# Altium schematic sheet PowerSupply.SchDoc(PowerSupply)
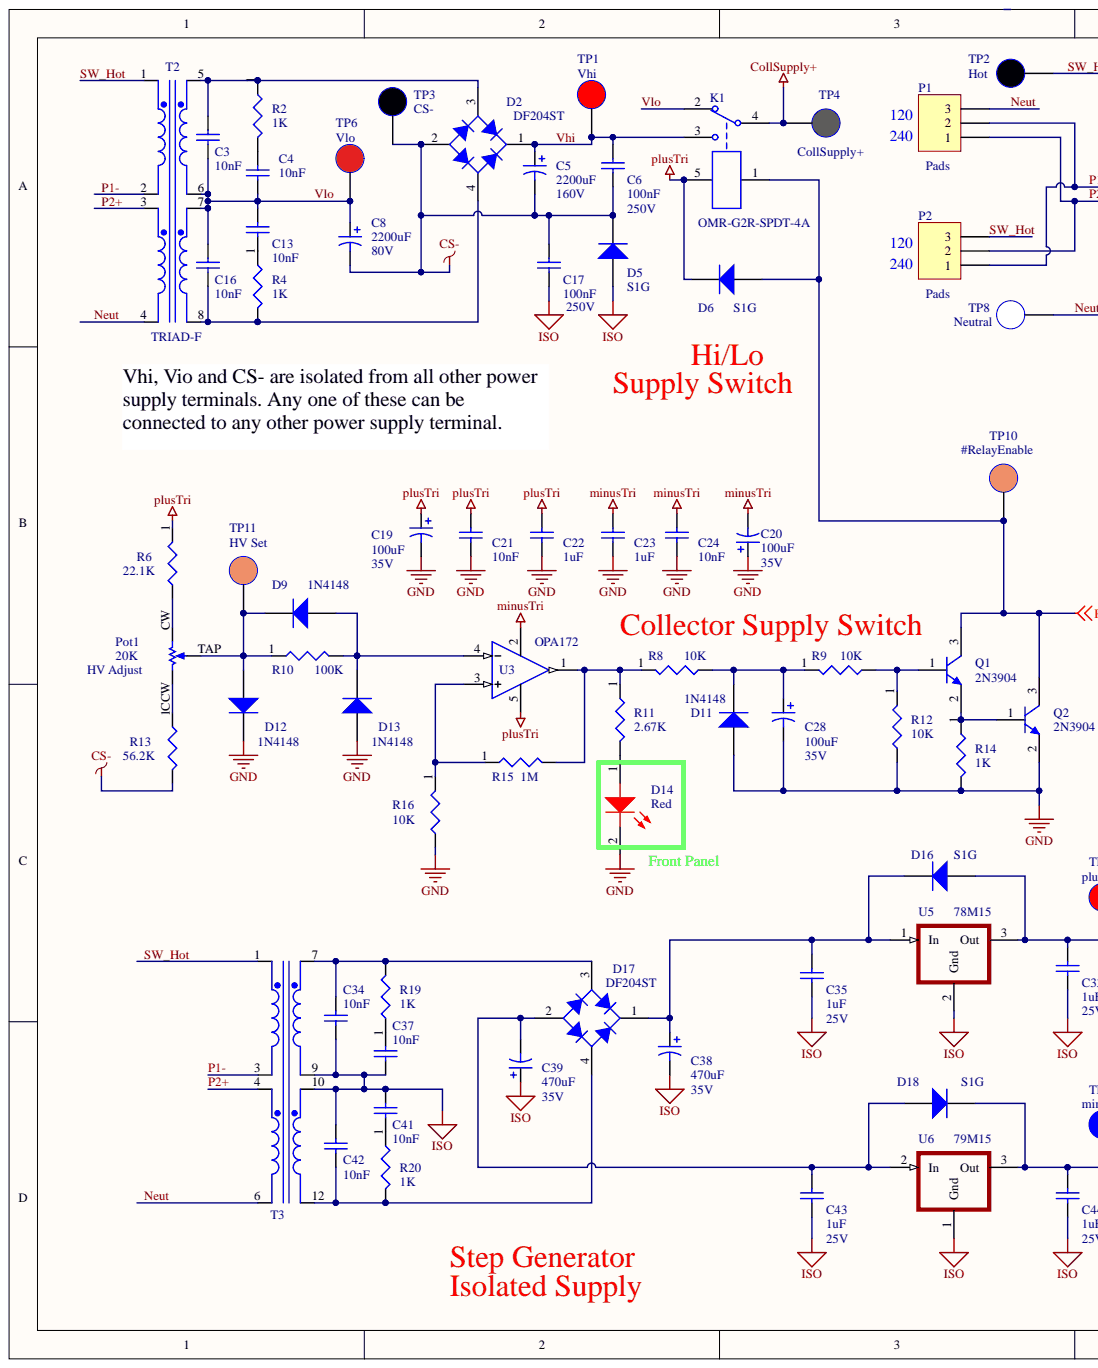
[diagram p0: PowerSupply.SchDoc, left]
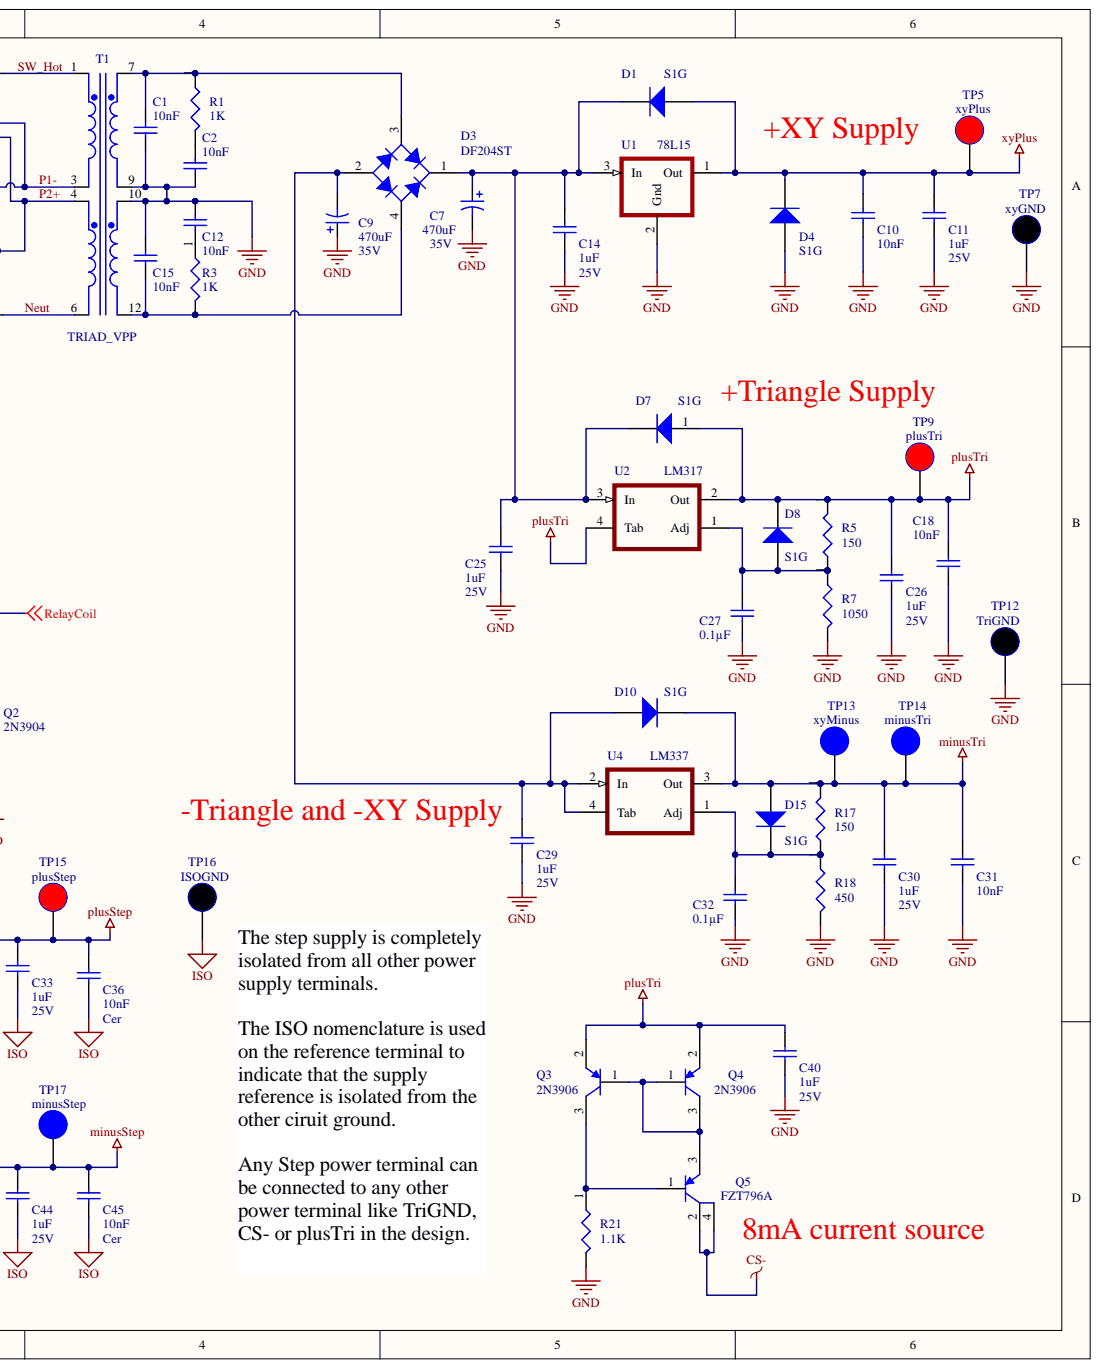
[diagram p1: PowerSupply.SchDoc, right]

## Parts
C1  10nF  [CAPC3216M]
C2  10nF  [CAPC3216M]
C3  Capacitance: 10nF  [CAPC3216M]  (hidden comment: 0.1uF)
C4  Capacitance: 10nF  [CAPC3216M]  (hidden comment: 0.1uF)
C5  2200uF  Voltage Rating (DC): 160V  [CAPR10-35X40]
C6  Capacitance: 100nF  [CAPC3216M]  (hidden comment: 0.1uF)
C7  470uF  [CAPPR5-10x12.5-STEP]
C8  2200uF  Voltage Rating (DC): 80V  [CAPR10-25-37]
C9  470uF  Voltage Rating: 35V  [CAPPR5-10x12.5-STEP]
C10  10nF  [CAPC3216M]
C11  1uF  Voltage Rating (DC): 25V  [CAPC3216M]
C12  10nF  [CAPC3216M]
C13  Capacitance: 10nF  [CAPC3216M]  (hidden comment: 0.1uF)
C14  1uF  Voltage Rating (DC): 25V  [CAPC3216M]
C15  10nF  [CAPC3216M]
C16  Capacitance: 10nF  [CAPC3216M]  (hidden comment: 0.1uF)
C17  Voltage Rating: 250V  Capacitance: 100nF  [CAPC3216M]  (hidden comment: 0.1uF)
C18  10nF  [CAPC3216M]
C19  100uF  Voltage Rating: 35V  [CAPPR2.5-6.3X10]
C20  100uF  Voltage Rating: 35V  [CAPPR2.5-6.3X10]
C21  10nF  [CAPC3216M]
C22  value 1uF  [CAPC3216M]  (hidden comment: Cap)
C23  value 1uF  [CAPC3216M]  (hidden comment: Cap)
C24  10nF  [CAPC3216M]
C25  1uF  Voltage Rating (DC): 25V  [CAPC3216M]
C26  1uF  Voltage Rating (DC): 25V  [CAPC3216M]
C27  Capacitance: 0.1µF  [CAPC3216M]  (hidden comment: 0.1uF)
C28  100uF  Voltage: 35V  [CAPPR2-5x11]
C29  1uF  Voltage Rating (DC): 25V  [CAPC3216M]
C30  1uF  Voltage Rating (DC): 25V  [CAPC3216M]
C31  10nF  [CAPC3216M]
C32  Capacitance: 0.1µF  [CAPC3216M]  (hidden comment: 0.1uF)
C33  1uF  Voltage Rating (DC): 25V  [CAPC3216M]
C34  Capacitance: 10nF  [CAPC3216M]  (hidden comment: 0.1uF)
C35  1uF  Voltage Rating (DC): 25V  [CAPC3216M]
C36  10nF  Dielectric Material: Cer  [CAPC3216M]
C37  Capacitance: 10nF  [CAPC3216M]  (hidden comment: 0.1uF)
C38  470uF  Voltage Rating: 35V  [CAPPR5-10x12.5-STEP]
C39  470uF  Voltage Rating: 35V  [CAPPR5-10x12.5-STEP]
C40  1uF  Voltage Rating (DC): 25V  [CAPC3216M]
C41  Capacitance: 10nF  [CAPC3216M]  (hidden comment: 0.1uF)
C42  Capacitance: 10nF  [CAPC3216M]  (hidden comment: 0.1uF)
C43  1uF  Voltage Rating (DC): 25V  [CAPC3216M]
C44  1uF  Voltage Rating (DC): 25V  [CAPC3216M]
C45  10nF  Dielectric Material: Cer  [CAPC3216M]
D1  S1G  [SMA-D]
D2  value DF204ST  [SOIC254P670X290-4M]  (hidden comment: Bridge)
D3  value DF204ST  [SOIC254P670X290-4M]  (hidden comment: Bridge)
D4  S1G  [SMA-D]
D5  S1G  [SMA-D]
D6  S1G  [SMA-D]
D7  S1G  [SMA-D]
D8  S1G  [SMA-D]
D9  1N4148  [SOD123]
D10  S1G  [SMA-D]
D11  1N4148  [SOD123]
D12  1N4148  [SOD123]
D13  1N4148  [SOD123]
D14  Red  [LED-RED-3MM]
D15  S1G  [SMA-D]
D16  S1G  [SMA-D]
D17  value DF204ST  [SOIC254P670X290-4M]  (hidden comment: Bridge)
D18  S1G  [SMA-D]
K1  OMR-G2R-SPDT-4A  [G2R--1-H]
P1  Pads  [3X1LgH]
P2  Pads  [3X1LgH]
Pot1  HV Adjust  value 20K  [3306P-CCW]
Q1  2N3904  [SOT23-3M]
Q2  2N3904  [SOT23-3M]
Q3  2N3906  [SOT23-3M]
Q4  2N3906  [SOT23-3M]
Q5  FZT796A  [SOT223-4M]
R1  1K  [RESC6432BM]
R2  1K  [RESC6432BM]
R3  1K  [RESC6432BM]
R4  1K  [RESC6432BM]
R5  value 150  [RESC3216M]  (hidden comment: 100)
R6  22.1K  [RESC3216M]
R7  value 1050  [RESC3216M]  (hidden comment: 499)
R8  10K  [RESC3216M]
R9  10K  [RESC3216M]
R10  100k  [RESC3216M]
R11  2.67K  [RESC3216M]
R12  10K  [RESC3216M]
R13  56.2K  [RESC3216M]
R14  1K  [RESC3216M]
R15  1M  [RESC3216M]
R16  10K  [RESC3216M]
R17  value 150  [RESC3216M]  (hidden comment: 100)
R18  value 450  [RESC3216M]  (hidden comment: 499)
R19  1K  [RESC6432BM]
R20  1K  [RESC6432BM]
R21  1.1K  [RESC3216M]
T1  TRIAD_VPP  [VPPXX-5W]
T2  TRIAD-F  [DST-36W]
T3  Power Transformer  [VPPXX-5W]  (value not drawn)
TP1  Vhi  [TP-.038]
TP2  Hot  [TP-.063]
TP3  CS-  [TP-.038]
TP4  CollSupply+  [TP-.038]
TP5  xyPlus  [TP-.038]
TP6  Vlo  [TP-.038]
TP7  xyGND  [TP-.038]
TP8  Neutral  [TP-.063]
TP9  plusTri  [TP-.038]
TP10  #RelayEnable  [TP-.038]
TP11  HV Set  [TP-.038]
TP12  TriGND  [TP-.038]
TP13  xyMinus  [TP-.038]
TP14  minusTri  [TP-.038]
TP15  plusStep  [TP-.038]
TP16  ISOGND  [TP-.038]
TP17  minusStep  [TP-.038]
U1  78L15  [SOT89M]
U2  LM317  [SOT223-4M]
U3  OPA172  [SOT23-5AM]
U4  LM337  [SOT223-4M]
U5  78M15  [DPAK-M]
U6  79M15  [DPAK-M]

## Nets
CollSupply+: K1.4 TP4.1
CS-: C5.2 C6.1 C8.2 C17.2 D2.2 D5.2 Q5.2 Q5.4 R13.2 TP3.1
GND: C1.2 C2.2 C7.2 C9.1 C10.1 C11.1 C12.1 C14.1 C15.1 C18.1 C19.2 C20.1 C21.1 C22.1 C23.1 C24.1 C25.1 C26.1 C27.1 C28.2 C29.1 C30.1 C31.1 C32.1 C40.1 D4.1 D11.1 D12.2 D13.1 D14.2 Q2.2 R7.2 R12.2 R14.2 R16.2 R18.2 R21.2 T1.9 T1.10 TP7.1 TP12.1 U1.2
ISO: C17.1 C33.1 C34.2 C35.1 C36.1 C37.2 C38.2 C39.1 C41.1 C42.1 C43.1 C44.1 C45.1 D5.1 T3.9 T3.10 TP16.1 U5.2 U6.1
minusStep: C44.2 C45.2 D18.2 TP17.1 U6.3
minusTri: C20.2 C23.2 C24.2 C30.2 C31.2 D10.2 D15.1 R17.1 TP13.1 TP14.1 U3.2 U4.3(Out)
plusStep: C33.2 C36.2 D16.1 TP15.1 U5.3
plusTri: C18.2 C19.1 C21.2 C22.2 C26.2 C40.2 D6.2 D7.1 D8.2 K1.5 Q3.2 Q4.2 R5.1 R6.1 TP9.1 U2.2(Out) U2.4(Tab) U3.5
RelayCoil: D6.1 K1.1 Q1.3 Q2.3 TP10.1
xyPlus: C10.2 C11.2 D1.1 D4.2 TP5.1 U1.1
Neut: P1.3 T1.6 T2.4 T3.6 TP8.1
P1-: P1.2 P2.1 T1.3 T2.2 T3.3
P2+: P1.1 P2.2 T1.4 T2.3 T3.4
SW_Hot: P2.3 T1.1 T2.1 T3.1 TP2.1
Vhi: C5.1 C6.2 D2.1 K1.3 TP1.1
Vlo: C3.1 C4.1 C8.1 C13.1 C16.2 K1.2 T2.6 T2.7 TP6.1
NetC1_1: C1.1 D3.3 R1.1 T1.7
NetC2_1: C2.1 R1.2
NetC3_2: C3.2 D2.3 R2.1 T2.5
NetC4_2: C4.2 R2.2
NetC7_1: C7.1 C14.2 C25.2 D1.2 D3.1 D7.2 U1.3 U2.3(In)
NetC9_2: C9.2 C29.2 D3.2 D10.1 U4.2(In) U4.4(Tab)
NetC12_2: C12.2 R3.1
NetC13_2: C13.2 R4.1
NetC15_2: C15.2 D3.4 R3.2 T1.12
NetC16_1: C16.1 D2.4 R4.2 T2.8
NetC27_2: C27.2 D8.1 R5.2 R7.1 U2.1(Adj)
NetC28_1: C28.1 D11.2 R8.2 R9.1
NetC32_2: C32.2 D15.2 R17.2 R18.1 U4.1(Adj)
NetC34_1: C34.1 D17.3 R19.2 T3.7
NetC35_2: C35.2 C38.1 D16.2 D17.1 U5.1
NetC37_1: C37.1 R19.1
NetC39_2: C39.2 C43.2 D17.2 D18.1 U6.2
NetC41_2: C41.2 R20.1
NetC42_2: C42.2 D17.4 R20.2 T3.12
NetD9_1: D9.1 D13.2 R10.2 U3.4
NetD9_2: D9.2 D12.1 Pot1.TAP R10.1 TP11.1
NetD14_1: D14.1 R11.2
NetPot1_CCW: Pot1.CCW R13.1
NetPot1_CW: Pot1.CW R6.2
NetQ1_1: Q1.1 R9.2 R12.1
NetQ1_2: Q1.2 Q2.1 R14.1
NetQ3_1: Q3.1 Q4.1 Q4.3 Q5.3
NetQ3_3: Q3.3 Q5.1 R21.1
NetR8_1: R8.1 R11.1 R15.2 U3.1
NetR15_1: R15.1 R16.1 U3.3
N00018:

## Library data of the parts
C1 C2 C12 C15 = Cap: CAP CER 1206 0.1UF 250V X7R; Manufacturer Part Number: C1206C104KARECAUTO; Manufacturer: KEMET; Tolerance: 10%; Capacitance: 100nF; Voltage Rating: 250V; Value: 10nF; Supplier Part Number: 399-17175-6-ND; Supplier: Digi-Key; Simulation: CAP; Signal Integrity: Cap; Ratings: AEC-Q200; Packaging: Cut Tape; Mount: Surface Mount; Min Operating Temperature: -55°C; Max Operating Temperature: 125°C; Library Name: Miscellaneous Devices.IntLib; Component Kind: Standard; Case Code (Metric): 3216; Case Code (Imperial): 1206; Case/Package: 1206
C3 C4 C6 C13 C16 C17 C34 C37 C41 C42 = Cap: CAP CER 1206 0.1UF 250V X7R; Manufacturer Part Number: C1206C104KARECAUTO; Manufacturer: KEMET; Tolerance: 10%; Value: 0.1uF; Supplier Part Number: 399-17175-6-ND; Supplier: Digi-Key; Simulation: CAP; Signal Integrity: Cap; Ratings: AEC-Q200; Packaging: Cut Tape; Mount: Surface Mount; Min Operating Temperature: -55°C; Max Operating Temperature: 125°C; Library Name: Miscellaneous Devices.IntLib; Component Kind: Standard; Case Code (Metric): 3216; Case Code (Imperial): 1206; Case/Package: 1206
  C3 C4 C6 C13 C16 C34 C37 C41 C42: Voltage Rating: 250V
C5 = Cap Pol3: CAP ALUM 2200UF 20% 160V SNAP; Manufacturer Part Number: SLPX222M160H7P3; Manufacturer: Cornell Dubilier; Test Frequency: 120Hz; Ripple Current: 4.96A; Life (Hours): 3000Hour; Lead Length: 6.3mm; Lead Diameter: 1.5mm; ESR (Equivalent Series Resistance): 90mR; Dielectric Material: Aluminium; Capacitance: 2.2mF; Voltage Rating: 160V; Voltage: 160V; Value: 2200uF; Tolerance: 20%; Termination: Snap in; Supplier Part Number: 338-1593-ND; Supplier: Digi-Key; Simulation: CAP; Signal Integrity: Cap Pol; Packaging: Bulk; Package Quantity: 40; Number of Pins: 2; Mount: Through Hole,PCB; Min Operating Temperature: -40°C; Max Operating Temperature: 85°C; Library Name: Miscellaneous Devices.IntLib; Length: 40mm; Lead Pitch: 0.394inch; Height - Seated (Max): 1.575inch; Height: 40mm; Diameter: 35mm; Component Kind: Standard; Case/Package: Radial
C7 C9 C38 C39 = Cap Pol3: CAP ALUM 470UF 20% 35V RADIAL; Manufacturer Part Number: 35ZL470MEFC10X20; Manufacturer: Rubycon; Ripple Current: 1.82A; Life (Hours): 4000Hour; Leakage Current: 3uA; Lead Diameter: 0.6mm; Impedance: 23mR; Dielectric Material: Aluminium; Voltage: 35V; Value: 470uF; Tolerance: 20%; Termination: Radial; Supplier Part Number: 35ZL470MEFC10X20-ND; Supplier: Digi-Key; Simulation: CAP; Signal Integrity: Cap Pol; REACH SVHC: Unknown; Radiation Hardening: No; Packaging: Bulk; Package Quantity: 1000; Mount: Through Hole; Min Operating Temperature: -40°C; Max Operating Temperature: 105°C; Library Name: Miscellaneous Devices.IntLib; Lead Pitch: 5mm; Lead Free: Lead Free; Height - Seated (Max): 0.866inch; Height: 20mm; Diameter: 10mm; Component Kind: Standard; Case/Package: Radial; Capacitance: 470uF
  C7: Voltage Rating: 35V
C8 = Cap Pol3: CAP ALUM 2200UF 20% 80V SNAP; Manufacturer Part Number: SLPX222M080C3P3; Manufacturer: Cornell Dubilier; Test Frequency: 120Hz; Ripple Current: 2.53A; Life (Hours): 3000Hour; Lead Length: 6.3mm; Lead Diameter: 1.5mm; ESR (Equivalent Series Resistance): 151mR; Dielectric Material: Aluminium; Capacitance: 2.2mF; Voltage Rating: 80V; Voltage: 80V; Value: 2200uF; Tolerance: 20%; Termination: Snap in; Supplier Part Number: 338-1590-ND; Supplier: Digi-Key; Simulation: CAP; Signal Integrity: Cap Pol; REACH SVHC: No SVHC; Packaging: Bulk; Package Quantity: 100; Number of Pins: 2; Mount: Through Hole,PCB; Min Operating Temperature: -40°C; Max Operating Temperature: 85°C; Library Name: Miscellaneous Devices.IntLib; Length: 30mm; Lead Pitch: 10mm; Height - Seated (Max): 1.181inch; Height: 30mm; Diameter: 25mm; Component Kind: Standard; Case/Package: Radial
C10 C18 C21 C24 C31 C36 C45 = Cap: CAP CER 1UF 25V X7R 1206; Manufacturer Part Number: C1206C103K3RACTU; Manufacturer: KEMET; Tolerance: 10%; Width: 1.6mm; Voltage Rating (DC): 25V; Voltage Rating: 25V; Value: 10nF; Thickness: 0.035inch; Termination: SMD/SMT; Supplier Part Number: 399-14644-1-ND; Supplier: Digi-Key; Simulation: CAP; Signal Integrity: Cap; Radiation Hardening: No; Packaging: Tape and Reel; Package Quantity: 4000; Mount: Surface Mount; Min Operating Temperature: -55°C; Max Operating Temperature: 125°C; Library Name: Miscellaneous Devices.IntLib; Length: 3.2mm; Height: 0.78mm; Dielectric: X7R; Depth: 1.6mm; Component Kind: Standard; Case Code (Metric): 3216; Case Code (Imperial): 1206; Case/Package: 1206; Capacitance: 10nF
  C10 C18 C21 C24 C31: Dielectric Material: Cer
C11 C14 C25 C26 C29 C30 C33 C35 C40 C43 C44 = Cap: KEMET         C1206C105K3RACTU            SMD Multilayer Ceramic Capacitor, C Series, 1 F,  10%, X7R, 25 V, 1206 [3216 Metric]; Width: 0.063inch; Voltage Rating: 25V; Voltage: 25V; Value: 1uF; Tolerance: 10%; Thickness: 0.055inch; Termination: SMD/SMT; Supplier Part Number: 399-1255-1-ND; Supplier: Digi-Key; Simulation: CAP; Signal Integrity: Cap; REACH SVHC: No SVHC; Radiation Hardening: No; Packaging: Tape and Reel; Package Quantity: 1; Mount: Surface Mount; Min Operating Temperature: -55°C; Max Operating Temperature: 125°C; Manufacturer Part Number: C1206C105K3RACTU; Manufacturer: KEMET; Library Name: Miscellaneous Devices.IntLib; Length: 3.2mm; Height: 1mm; Dielectric Material: Ceramic; Dielectric: X7R; Depth: 1.6mm; Contact Plating: Tin,Matte; Component Kind: Standard; Case Code (Metric): 3216; Case Code (Imperial): 1206; Case/Package: 1206; Capacitance: 1uF
C19 C20 = Cap Pol1: CAP ALUM 100UF 20% 35V THRU HOLE; Manufacturer Part Number: 35YXJ100M6.3X11; Manufacturer: Rubycon; Ripple Current: 400mA; Polarity: Polar; Life (Hours): 7000Hour; Leakage Current: 3uA; Lead Diameter: 0.5mm; Impedance: 220mR; Voltage: 35V; Value: 100uF; Tolerance: 20%; Termination: Radial; Supplier Part Number: 1189-2260-ND; Supplier: Digi-Key; Simulation: CAP; Signal Integrity: Cap Pol; Radiation Hardening: No; Packaging: Bulk; Package Quantity: 3000; Mount: Through Hole; Min Operating Temperature: -40°C; Max Operating Temperature: 105°C; Library Name: Miscellaneous Devices.IntLib; Lead Pitch: 2.5mm; Height - Seated (Max): 0.492inch; Height: 11mm; Diameter: 6.3mm; Component Kind: Standard; Case/Package: Radial; Capacitance: 100uF
C22 C23 = Cap: CAP CER 1UF 25V X7R 1206; Manufacturer Part Number: C1206C105M3RACTU; Manufacturer: Kemet; Tolerance: ±20%; Dielectric Material: Cer.; Capacitance: 1µF; Voltage - Rated: 25V; Thickness (Max): 0.055" (1.40mm); Temperature Coefficient: X7R; Supplier Part Number: 399-8148-1-ND; Supplier: Digi-Key; Size / Dimension: 0.126" L x 0.063" W (3.20mm x 1.60mm); Simulation: CAP; Signal Integrity: Cap; RoHS: RoHS Compliant; Packaging: Cut Tape (CT); Package / Case: 1206 (3216 Metric); Operating Temperature: -55°C ~ 125°C; Mounting Type: Surface Mount, MLCC; Library Name: Miscellaneous Devices.IntLib; Component Kind: Standard; Category: Capacitors; Applications: General Purpose
C27 C32 = Cap: Capacitor; Manufacturer Part Number: C0805C104K5RACTU; Manufacturer: Kemet; Voltage - Rated: 50V; Tolerance: ±10%; Temperature Coefficient: X7R; Value: 0.1uF; Supplier Part Number: 399-1170-1-ND; Supplier: Digi-Key; Simulation: CAP; Signal Integrity: Cap; Package / Case: 0805 (2012 Metric); Library Name: Miscellaneous Devices.IntLib; Component Kind: Standard
C28 = Cap Pol1: Polarized Capacitor (Radial); Manufacturer Part #: ECA-1VM470; Manufacturer: Panasonic; Type: Alum; Value: 100uF; Simulation: CAP; Signal Integrity: Cap Pol; Published: 8-Jun-2000; PackageReference: RB7.6-15; Library Name: Miscellaneous Devices.IntLib; LatestRevisionNote: Re-released for DXP Platform.; LatestRevisionDate: 17-Jul-2002; Digikey Part #: P5164; Component Kind: Standard; Publisher: Altium Limited
D1 D4-D8 D10 D15 D16 D18 = Diode 1N4002: Diode Switching 400V 1A 2-Pin DO-214AC T/R; Manufacturer: ON Semiconductor / Fairchild; Reverse Recovery Time: 1.8us; Recovery Time: 1800ns; Power Dissipation: 1.4W; Polarity: Standard; Peak Reverse Current: 1uA; Peak Non-Repetitive Surge Current: 30A; Max Surge Current: 40A; Forward Voltage: 1.1V; Element Configuration: Single; Capacitance: 12pF; Width: 2.95mm; Weight: 0.106g; Voltage Rating (DC): 400V; Supplier Part Number: S1GFSCT-ND; Supplier: Digi-Key; Simulation: 1N4002; Signal Integrity: 1N4002; Reverse Voltage: 400V; REACH SVHC: No SVHC; Radiation Hardening: No; Packaging: Tape and Reel; Package Quantity: 1; Output Current: 1A; Number of Pins: 2; Mount: Surface Mount; Min Operating Temperature: -55°C; Max Reverse Voltage (DC): 400V; Max Repetitive Reverse Voltage (Vrrm): 400V; Max Operating Temperature: 150°C; Max Forward Surge Current (Ifsm): 30A; Manufacturer Part Number: S1G; Library Name: Miscellaneous Devices.IntLib; Length: 4.75mm; Lead Free: Lead Free; Height: 2.2mm; Forward Current: 1A; Current Rating: 1A; Contact Plating: Tin,Matte; ComponentLink2URL: http://www.fairchildsemi.com/ds/1N%2F1N4001.pdf; ComponentLink2Description: Datasheet; ComponentLink1URL: http://www.fairchildsemi.com/; ComponentLink1Description: Manufacturer Link; Component Kind: Standard; Case/Package: SMA; Average Rectified Current: 1A
D2 D3 D17 = Bridge1: RECT BRIDGE GPP 400V 2A DFS; Manufacturer Part Number: DF204ST-G; Manufacturer: Comchip; Max Surge Current: 60A; Max Reverse Leakage Current: 10uA; Width: 10.41mm; Supplier Part Number: 641-1464-1-ND; Supplier: Digi-Key; Simulation: BRIDGE; Packaging: Tape and Reel; Package Quantity: 1; Number of Pins: 4; Mount: Surface Mount; Min Operating Temperature: -55°C; Max Operating Temperature: 150°C; Library Name: Miscellaneous Devices.IntLib; Length: 8.64mm; Height: 3.81mm; Component Kind: Standard; Case/Package: SMD/SMT
D9 D11-D13 = Diode 1N4148: DIODE GP 75V 150MA SOD123FL; Manufacturer Part Number: 1N4148WFL-G3-08; Manufacturer: Vishay Semiconductor Diodes Division; Voltage - Forward (Vf) (Max) @ If: 1.2V @ 100mA; Speed: Small Signal =< 200mA (Io), Any Speed; Reverse Recovery Time (trr): 4ns; Diode Type: Standard; Current - Reverse Leakage @ Vr: 5µA @ 75V; Voltage - DC Reverse (Vr) (Max): 75V; Supplier Part Number: 1N4148WFL-G3-08GICT-ND; Supplier Device Package: SOD-123FL; Supplier: Digi-Key; Simulation: 1N4148; Signal Integrity: 1N4148; RoHS: RoHS Compliant; Published: 8-Jun-2000; Packaging: Cut Tape (CT); PackageReference: DO-35; PackageDescription: Diode, Axial; Body 3.8 x 1.9 mm (LxDia. max), Lead Dia. 0.53 mm (max); Package / Case: SOD-123F; Operating Temperature - Junction: 150°C (Max); Mounting Type: Surface Mount; Library Name: Miscellaneous Devices.IntLib; LatestRevisionNote: Re-released for DXP Platform.; LatestRevisionDate: 17-Jul-2002; DatasheetVersion: 1997; Current - Average Rectified (Io): 150mA; ComponentLink2URL: http://www.fairchildsemi.com/ds/1N%2F1N4148.pdf; ComponentLink2Description: Datasheet; ComponentLink1URL: http://www.fairchildsemi.com/; ComponentLink1Description: Manufacturer Link; Component Kind: Standard; Code_JEDEC: DO-204-AH; Category: Discrete Semiconductor Products; Publisher: Altium Limited
K1 = SPDT-OMR-G2R-1-H: RELAY GEN PURPOSE SPDT 5A 12V; Manufacturer Part Number: G2R-1-H-DC12; Manufacturer: Omron; Release Time: 5ms; Relay Type: General Purpose; Operate Time: 15ms; Max Voltage Rating (DC): 125V; Max Voltage Rating (AC): 380V; Coil Type: Non-Latching; Coil Resistance: 400R; Coil Power: 360mW; Coil Current: 30mA; Weight: 17g; Throw Configuration: SPDT; Termination: PC Pin; Supplier Part Number: Z11701-ND; Supplier: Digi-Key; Packaging: Bulk; Package Quantity: 100; Number of Pins: 5; Mount: Through Hole; Min Operating Temperature: -40°C; Max Operating Temperature: 70°C; Max Current Rating: 5A; Library Name: My_Relays.SchLib; Lead Free: Lead Free; Contact Material: Silver; Contact Current Rating: 5A; Component Kind: Standard; Coil Voltage (DC): 12V
P1 P2 = Header 3: Header, 3-Pin; Signal Integrity: Connector; Library Name: Miscellaneous Connectors.IntLib; LatestRevisionNote: Re-released for DXP Platform.; LatestRevisionDate: 17-Jul-2002; Component Kind: Standard; Publisher: Altium Limited
Pot1 = POT-Single: TRIMMER 10K OHM 0.2W TH; Manufacturer Part Number: 3306P-1-103; Manufacturer: Bourns; Voltage Rating (DC): 100V; Tolerance: 25%; Temperature Coefficient: 250ppm/°C; Resistance: 10kR; Power Rating: 200mW; Pitch: 2.5mm; Number of Turns: 1; Lead Diameter: 1mm; Width: 6.81mm; Weight: 360mg; Voltage Rating: 100V; Type: Potentiometer; Termination: Pin; Taper: Linear; Supplier Part Number: 3306P-103-ND; Supplier: Digi-Key; REACH SVHC: No SVHC; Radiation Hardening: No; Packaging: Bulk; Package Quantity: 200; Number of Terminals: 3; Mount: Through Hole,PCB; Min Operating Temperature: -25°C; Military Standard: Not; Max Power Dissipation: 200mW; Max Operating Temperature: 100°C; Length: 6.81mm; Lead Free: Lead Free; Height: 7.62mm; Diameter: 6.81mm; Depth: 8.1mm; Composition: Cermet; Component Kind: Standard; Colour: Blue; Color: Blue; Library Name: MY_POTS.SchLib
Q1 Q2 = 2N3904: TRANS NPN 40V 0.2A SOT23; Manufacturer Part Number: MMBT3904LT1G; Manufacturer: ON Semiconductor; Vce Saturation (Max) @ Ib, Ic: 300mV @ 5mA, 50mA; Power - Max: 300mW; Frequency - Transition: 300MHz; DC Current Gain (hFE) (Min) @ Ic, Vce: 100 @ 10mA, 1V; Current - Collector (Ic) (Max): 200mA; Voltage - Collector Emitter Breakdown (Max): 40V; Transistor Type: NPN; Supplier Part Number: MMBT3904LT1GOSCT-ND; Supplier Device Package: SOT-23-3 (TO-236); Supplier: Digi-Key; Simulation: 2N3904; Signal Integrity: 2N3904; RoHS: RoHS Compliant; Published: 8-Jun-2000; Part Status: Active; Packaging: Cut Tape (CT); PackageVersion: Sep-1998; PackageReference: TO-92A; Package / Case: TO-236-3, SC-59, SOT-23-3; Operating Temperature: -55°C ~ 150°C (TJ); Mounting Type: Surface Mount; Library Name: My_Miscellaneous Devices.SchLib; LatestRevisionNote: PCB Footprint 'BCY-W3/D4.7' replaced; LatestRevisionDate: 15-Jan-2003; DatasheetVersion: 1997; ComponentLink2URL: http://www.fairchildsemi.com/ds/2N%2F2N3904.pdf; ComponentLink2Description: Datasheet; ComponentLink1URL: http://www.fairchildsemi.com/; ComponentLink1Description: Manufacturer Link; Component Kind: Standard; Code_JEDEC: TO-92A; Category: Discrete Semiconductor Products; Publisher: Altium Limited
Q3 Q4 = 2N3906: TRANS PNP 40V 0.2A SOT23; Manufacturer Part Number: MMBT3906LT1G; Manufacturer: ON Semiconductor; Vce Saturation (Max) @ Ib, Ic: 400mV @ 5mA, 50mA; Power - Max: 300mW; Frequency - Transition: 250MHz; DC Current Gain (hFE) (Min) @ Ic, Vce: 100 @ 10mA, 1V; Current - Collector (Ic) (Max): 200mA; Voltage - Collector Emitter Breakdown (Max): 40V; Value: 2N3906; Transistor Type: PNP; Supplier Part Number: MMBT3906LT1GOSCT-ND; Supplier Device Package: SOT-23-3 (TO-236); Supplier: Digi-Key; Simulation: 2N3906; Signal Integrity: 2N3906; RoHS: RoHS Compliant; Packaging: Cut Tape (CT); Package / Case: TO-236-3, SC-59, SOT-23-3; Mounting Type: Surface Mount; Library Name: Miscellaneous Devices.IntLib; Component Kind: Standard; Category: Discrete Semiconductor Products
Q5 = PNP-2C: TRANS PNP 200V 0.5A SOT-223; Manufacturer Part Number: FZT796ATA; Manufacturer: Diodes Zetex; Voltage Rating (DC): -200V; Transition Frequency: 100MHz; Power Dissipation: 2W; Number of Elements: 1; Max Collector Current: 500mA; Emitter Base Voltage (VEBO): -5V; Element Configuration: Single; Current Rating: -500mA; Collector Emitter Saturation Voltage: -300mV; Width: 3.7mm; Weight: 0.000282oz; Value: FZT796A; Supplier Part Number: FZT796ACT-ND; Supplier: Digi-Key; REACH SVHC: No SVHC; Radiation Hardening: No; Polarity: PNP; Packaging: Tape and Reel; Package Quantity: 1000; Number of Pins: 4; Mount: Surface Mount; Min Operating Temperature: -55°C; Max Power Dissipation: 2W; Max Operating Temperature: 150°C; Max Frequency: 100MHz; Max Breakdown Voltage: 200V; Library Name: My_Miscellaneous Devices.SchLib; Length: 6.7mm; Lead Free: Lead Free; Height: 1.65mm; Gain Bandwidth Product: 100MHz; Frequency: 100MHz; Continuous Collector Current: -500mA; Component Kind: Standard; Collector Emitter Voltage (VCEO): 200V; Collector Emitter Breakdown Voltage: 200V; Collector Base Voltage (VCBO): 200V; Case/Package: SOT-223
R1-R4 R14 R19 R20 = Res3: RES 1K OHM 1% 1/4W 1206; Manufacturer Part Number: RMCF1206FT1K00; Manufacturer: Stackpole Electronics; Temperature Coefficient: 100ppm/°C; Resistance: 1kR; Power Rating: 250mW; Width: 0.063inch; Value: 1K; Tolerance: 1%; Supplier Part Number: RMCF1206FT1K00CT-ND; Supplier: Arrow; Simulation: RESISTOR; Signal Integrity: Res; Radiation Hardening: No; Packaging: Tape and Reel; Number of Terminations: 2; Mount: Surface Mount; Min Operating Temperature: -55°C; Military Standard: Not; Max Power Dissipation: 250mW; Max Operating Temperature: 155°C; Library Name: Miscellaneous Devices.IntLib; Length: 3.2mm; Lead Free: Lead Free; Height: 0.028inch; Features: Automotive AEC-Q200; Depth: 1.6mm; Contact Plating: Matte,Tin; Composition: Thick Film; Component Kind: Standard; Case Code (Metric): 3216; Case Code (Imperial): 1206; Case/Package: 1206
R5 R17 = Res3: RES 100 OHM 1% 1/4W 1206; Manufacturer Part Number: RMCF1206FT100R; Manufacturer: Stackpole Electronics; Temperature Coefficient: 100ppm/°C; Resistance: 100R; Power Rating: 250mW; Width: 0.063inch; Tolerance: 1%; Supplier Part Number: RMCF1206FT100RCT-ND; Supplier: Digi-Key; Simulation: RESISTOR; Signal Integrity: Res; Radiation Hardening: No; Packaging: Tape and Reel; Number of Terminations: 2; Mount: Surface Mount; Min Operating Temperature: -55°C; Military Standard: Not; Max Power Dissipation: 250mW; Max Operating Temperature: 155°C; Library Name: Miscellaneous Devices.IntLib; Length: 0.126inch; Lead Free: Lead Free; Height: 0.028inch; Features: Automotive AEC-Q200; Depth: 1.6mm; Contact Plating: Matte,Tin; Composition: Thick Film; Component Kind: Standard; Case Code (Metric): 3216; Case Code (Imperial): 1206; Case/Package: 1206
R6 = Res3: RES 22.1K OHM 1% 1/4W 1206; Manufacturer Part Number: RMCF1206FT22K1; Manufacturer: Stackpole Electronics; Temperature Coefficient: 100ppm/°C; Resistance: 22.1kR; Power Rating: 250mW; Width: 0.063inch; Value: 22.1K; Tolerance: 1%; Supplier Part Number: RMCF1206FT2K21CT-ND; Supplier: Digi-Key; Simulation: RESISTOR; Signal Integrity: Res; Radiation Hardening: No; Packaging: Tape and Reel; Package Quantity: 1; Number of Terminations: 2; Mount: Surface Mount; Min Operating Temperature: -55°C; Military Standard: Not; Max Power Dissipation: 250mW; Max Operating Temperature: 155°C; Library Name: Miscellaneous Devices.IntLib; Length: 0.126inch; Lead Free: Lead Free; Height: 0.028inch; Features: Automotive AEC-Q200; Depth: 1.6mm; Contact Plating: Matte,Tin; Composition: Thick Film; Component Kind: Standard; Case Code (Metric): 3216; Case Code (Imperial): 1206; Case/Package: 1206
R7 R18 = Res3: RES 499 OHM 1% 1/4W 1206; Manufacturer Part Number: RMCF1206FT499R; Manufacturer: Stackpole Electronics; Temperature Coefficient: 100ppm/°C; Resistance: 499R; Power Rating: 250mW; Width: 0.063inch; Tolerance: 1%; Supplier Part Number: RMCF1206FT499RCT-ND; Supplier: Digi-Key; Simulation: RESISTOR; Signal Integrity: Res; Radiation Hardening: No; Packaging: Tape and Reel; Number of Terminations: 2; Mount: Surface Mount; Min Operating Temperature: -55°C; Military Standard: Not; Max Power Dissipation: 250mW; Max Operating Temperature: 155°C; Library Name: Miscellaneous Devices.IntLib; Length: 0.126inch; Lead Free: Lead Free; Height: 0.028inch; Features: Automotive AEC-Q200; Depth: 1.6mm; Contact Plating: Matte,Tin; Composition: Thick Film; Component Kind: Standard; Case Code (Metric): 3216; Case Code (Imperial): 1206; Case/Package: 1206
R8 R9 R12 R16 = Res3: RES 10K OHM 1% 1/4W 1206; Manufacturer Part Number: RMCF1206FT10K0; Manufacturer: Stackpole Electronics; Voltage Rating (DC): 200V; Temperature Coefficient: 100ppm/°C; Resistance: 10kR; Power Rating: 250mW; Width: 0.063inch; Voltage Rating: 200V; Value: 10K; Tolerance: 1%; Termination: SMD/SMT; Supplier Part Number: RMCF1206FT10K0CT-ND; Supplier: Digi-Key; Simulation: RESISTOR; Signal Integrity: Res; REACH SVHC: No SVHC; Radiation Hardening: No; Packaging: Tape and Reel; Package Quantity: 1; Number of Terminations: 2; Number of Pins: 2; Mount: Surface Mount; Min Operating Temperature: -55°C; Military Standard: Not; Max Power Dissipation: 250mW; Max Operating Temperature: 155°C; Library Name: Miscellaneous Devices.IntLib; Length: 3.2mm; Lead Free: Lead Free; Height: 0.028inch; Features: Automotive AEC-Q200; Depth: 1.6mm; Contact Plating: Matte,Tin; Composition: Thick Film; Component Kind: Standard; Case Code (Metric): 3216; Case Code (Imperial): 1206; Case/Package: 1206
R10 = Res3: RES 100K OHM 1% 1/4W 1206; Manufacturer Part Number: RMCF1206FT100K; Manufacturer: Stackpole Electronics; Temperature Coefficient: 100ppm/°C; Resistance: 100kR; Power Rating: 250mW; Width: 0.063inch; Value: 100K; Tolerance: 1%; Supplier Part Number: RMCF1206FT100KCT-ND; Supplier: Digi-Key; Simulation: RESISTOR; Signal Integrity: Res; Radiation Hardening: No; Packaging: Tape and Reel; Number of Terminations: 2; Mount: Surface Mount; Min Operating Temperature: -55°C; Military Standard: Not; Max Power Dissipation: 250mW; Max Operating Temperature: 155°C; Library Name: Miscellaneous Devices.IntLib; Length: 0.126inch; Lead Free: Lead Free; Height: 0.028inch; Features: Automotive AEC-Q200; Depth: 1.6mm; Contact Plating: Matte,Tin; Composition: Thick Film; Component Kind: Standard; Case Code (Metric): 3216; Case Code (Imperial): 1206; Case/Package: 1206
R11 = Res3: RES 2.67K OHM 1% 1/4W 1206; Manufacturer Part Number: RMCF1206FT2K74; Manufacturer: Stackpole Electronics; Temperature Coefficient: 100ppm/°C; Resistance: 2.74kR; Power Rating: 250mW; Width: 0.063inch; Value: 2.67K; Tolerance: 1%; Supplier Part Number: RMCF1206FT2K67CT-ND; Supplier: Digi-Key; Simulation: RESISTOR; Signal Integrity: Res; Radiation Hardening: No; Packaging: Tape and Reel; Number of Terminations: 2; Mount: Surface Mount; Min Operating Temperature: -55°C; Military Standard: Not; Max Power Dissipation: 250mW; Max Operating Temperature: 155°C; Library Name: Miscellaneous Devices.IntLib; Length: 0.126inch; Lead Free: Lead Free; Height: 0.028inch; Features: Automotive AEC-Q200; Depth: 1.6mm; Contact Plating: Matte,Tin; Composition: Thick Film; Component Kind: Standard; Case Code (Metric): 3216; Case Code (Imperial): 1206; Case/Package: 1206
R13 = Res3: RES 56.2K OHM 1% 1/4W 1206; Manufacturer Part Number: RMCF1206FT56K2; Manufacturer: Stackpole Electronics; Temperature Coefficient: 100ppm/°C; Resistance: 56.2kR; Power Rating: 250mW; Width: 0.063inch; Value: 56.2K; Tolerance: 1%; Supplier Part Number: RMCF1206F56K2CT-ND; Supplier: Digi-Key; Simulation: RESISTOR; Signal Integrity: Res; Radiation Hardening: No; Packaging: Tape and Reel; Package Quantity: 1; Number of Terminations: 2; Mount: Surface Mount; Min Operating Temperature: -55°C; Military Standard: Not; Max Power Dissipation: 250mW; Max Operating Temperature: 155°C; Library Name: Miscellaneous Devices.IntLib; Length: 0.126inch; Lead Free: Lead Free; Height: 0.028inch; Features: Automotive AEC-Q200; Depth: 1.6mm; Contact Plating: Tin,Matte; Composition: Thick Film; Component Kind: Standard; Case Code (Metric): 3216; Case Code (Imperial): 1206; Case/Package: 1206
R15 = Res3: RES 1M OHM 1% 1/4W 1206; Manufacturer Part Number: RMCF1206FT1M00; Manufacturer: Stackpole Electronics; Temperature Coefficient: 100ppm/°C; Resistance: 1MR; Power Rating: 250mW; Width: 0.063inch; Value: 1M; Tolerance: 1%; Supplier Part Number: RMCF1206FT1M00CT-ND; Supplier: Digi-Key; Simulation: RESISTOR; Signal Integrity: Res; Radiation Hardening: No; Packaging: Tape and Reel; Number of Terminations: 2; Mount: Surface Mount; Min Operating Temperature: -55°C; Military Standard: Not; Max Power Dissipation: 250mW; Max Operating Temperature: 155°C; Library Name: Miscellaneous Devices.IntLib; Length: 0.126inch; Lead Free: Lead Free; Height: 0.028inch; Features: Automotive AEC-Q200; Depth: 1.6mm; Contact Plating: Matte,Tin; Composition: Thick Film; Component Kind: Standard; Case Code (Metric): 3216; Case Code (Imperial): 1206; Case/Package: 1206
R21 = Res3: RES 1.1K OHM 1% 1/4W 1206; Manufacturer Part Number: RMCF1206FT1K10; Manufacturer: Stackpole Electronics; Temperature Coefficient: 100ppm/°C; Resistance: 1k1R; Power Rating: 250mW; Width: 0.063inch; Value: 1.1K; Tolerance: 1%; Supplier Part Number: RMCF1206FT1K10CT-ND; Supplier: Arrow; Simulation: RESISTOR; Signal Integrity: Res; Radiation Hardening: No; Packaging: Tape and Reel; Number of Terminations: 2; Mount: Surface Mount; Min Operating Temperature: -55°C; Military Standard: Not; Max Power Dissipation: 250mW; Max Operating Temperature: 155°C; Library Name: Miscellaneous Devices.IntLib; Length: 3.2mm; Lead Free: Lead Free; Height: 0.028inch; Features: Automotive AEC-Q200; Depth: 1.6mm; Contact Plating: Matte,Tin; Composition: Thick Film; Component Kind: Standard; Case Code (Metric): 3216; Case Code (Imperial): 1206; Case/Package: 1206
T3 = TRIAD_VPP: Library Name: My_Trans.SchLib; Component Kind: Standard
TP1 TP5 TP9 TP15 = TP-Round-Red: Library Name: MY_TP.SchLib; Component Kind: Standard
TP2 TP3 TP7 TP12 TP16 = TP-Round-Black: Library Name: MY_TP.SchLib; Component Kind: Standard
TP4 = TP-Round-Green: Library Name: MY_TP.SchLib; Component Kind: Standard
TP6 = TP-Round-Orange: Library Name: MY_TP.SchLib; Component Kind: Standard
TP8 = TP-Round-White: Library Name: MY_TP.SchLib; Component Kind: Standard
TP10 TP11 = TP-Round-Yellow: Library Name: MY_TP.SchLib; Component Kind: Standard
TP13 TP14 TP17 = TP-Round-Blue: Library Name: MY_TP.SchLib; Component Kind: Standard
U1 = 78L00-3PIN: TEXAS INSTRUMENTS         UA78L15ACPK            Linear Voltage Regulator, Fixed, 3-Terminal, Positive, 17.5V To 30V In, 15V And 0.1A Out, SOT-89-3; Quiescent Current: 6mA; Power Supply Rejection Ratio (PSRR): 39dB; Output Voltage Accuracy: 5%; Output Current: 100mA; Number of Regulators: 1; Dropout Voltage: 1.7V; Width: 2.5mm; Value: 78L15; Supplier Part Number: 296-12002-1-ND; Supplier: Digi-Key; REACH SVHC: No SVHC; Radiation Hardening: No; Polarity: Positive; Packaging: Tape and Reel; Package Quantity: 1; Output Voltage: 15V; Output Type: Fixed; Number of Pins: 3; Number of Outputs: 1; Nominal Output Voltage: 15V; Min Output Voltage: 15V; Min Operating Temperature: -40°C; Min Input Voltage: 17.5V; Max Output Voltage: 15V; Max Output Current: 100mA; Max Operating Temperature: 125°C; Max Input Voltage: 30V; Manufacturer Part Number: UA78L15ACPK; Manufacturer: Texas Instruments; Length: 4.5mm; Lead Free: Lead Free; Height: 1.5mm; Contact Plating: Tin,Matte; Component Kind: Standard; Case/Package: SOT-89; Accuracy: 5%; Library Name: MY_Vregs.SchLib
U2 = LM317: GLOVE, POLYAMIDE, L/FREE, PU, SIZE 6 - More Details; Manufacturer Part Number: LM317AEMP/NOPB; Manufacturer: TI National Semiconductor; Voltage: 37V; Reference Voltage: 1.262V; Quiescent Current: 60uA; Power Supply Rejection Ratio (PSRR): 80dB; Polarity: Positive; Output Voltage Accuracy: 1%; Output Type: Adjustable; Output Current: 1A; Number of Outputs: 1; Min Output Voltage: 1.2V; Min Input Voltage: 4.2V; Max Input Voltage: 40V; Dropout Voltage: 170mV; Width: 3.56mm; Value: LM317; Supplier Part Number: LM317AEMP/NOPBCT-ND; Supplier: Digi-Key; REACH SVHC: No SVHC; Radiation Hardening: No; Packaging: Tape and Reel; Package Quantity: 1000; Output Voltage: 37V; Number of Pins: 4; Mount: Surface Mount; Min Operating Temperature: -40°C; Max Output Voltage: 37V; Max Output Current: 1A; Max Operating Temperature: 125°C; Length: 6.5mm; Lead Free: Lead Free; Height: 1.6mm; Current Rating: 1A; Component Kind: Standard; Case/Package: SOT-223; Accuracy: 1%; Library Name: MY_Vregs.SchLib
U3 = TLV2371_5SOT23: OPA172IDCKT 10 MHz,10 V/s, 4.5V to 36V, SC-70; Manufacturer Part Number: OPA172IDBVR; Manufacturer: Texas Instruments; Voltage Gain: 130dB; Value: TLV271; Slew Rate: 10V/µs; Power Supply Rejection Ratio (PSRR): -120dB; Output Current per Channel: 75mA; Operating Supply Current: 1.6mA; Number of Circuits: 1; Min Dual Supply Voltage: 2.25V; Max Dual Supply Voltage: 18V; Input Offset Voltage (Vos): 200uV; Input Bias Current: 8pA; Gain Bandwidth Product: 10MHz; Common Mode Rejection Ratio: 90dB; Supplier Part Number: 296-40433-1-ND; Supplier: Digi-Key; Simulation: TLV2371; REACH SVHC: No SVHC; Packaging: Tape and Reel; Number of Pins: 5; Number of Channels: 1; Number of Amplifiers: 1; Mount: Surface Mount; Min Supply Voltage: 4.5V; Min Operating Temperature: -40°C; Max Supply Voltage: 36V; Max Operating Temperature: 125°C; Lead Free: Lead Free; Contact Plating: Gold; Component Kind: Standard; Case/Package: SOT-23-5; Bandwidth: 10MHz; Library Name: TI-OPAMPS.SchLib
U4 = LM337: TEXAS INSTRUMENTS   LM337IMP/NOPB   V REG ADJ -1.2/37V, SOT-223-4, 337, REEL; Tolerance: 3%; Reference Voltage: -1.287V; Quiescent Current: 3mA; Power Supply Rejection Ratio (PSRR): 77dB; Power Dissipation: 2W; Polarity: Negative; Output Voltage: -37V; Output Type: Adjustable; Output Current: 1A; Number of Outputs: 1; Min Input Voltage: -4.2V; Max Output Voltage: -1.2V; Max Input Voltage: -50V; Dropout Voltage: 2.4V; Width: 3.56mm; Weight: 0.006632oz; Value: LM337; Supplier Part Number: LM337IMP/NOPBCT-ND; Supplier: Digi-Key; REACH SVHC: No SVHC; Packaging: Tape and Reel; Number of Pins: 4; Mount: Surface Mount; Min Output Voltage: -37V; Min Operating Temperature: -40°C; Max Power Dissipation: 2W; Max Output Current: 1A; Max Operating Temperature: 125°C; Manufacturer Part Number: LM337IMP/NOPB; Manufacturer: Texas Instruments; Length: 6.5mm; Lead Free: Lead Free; Height: 1.6mm; Component Kind: Standard; Case/Package: SOT-223; Library Name: MY_Vregs.SchLib
U5 = 78M00-3PIN: IC REG LINEAR 15V 500MA DPAK; Manufacturer Part Number: MC78M15CDTRKG; Manufacturer: ON Semiconductor; Power Supply Rejection Ratio (PSRR): 70dB; Polarity: Positive; Output Type: Fixed; Number of Regulators: 1; Min Input Voltage: 17V; Max Input Voltage: 35V; Dropout Voltage: 2V; Width: 6.22mm; Value: 78M15; Supplier Part Number: MC78M15CDTRKGOSDKR-ND; Supplier: Digi-Key; REACH SVHC: No SVHC; Radiation Hardening: No; Packaging: Tape and Reel; Package Quantity: 1; Output Voltage: 15V; Output Current: 500mA; Number of Pins: 3; Number of Outputs: 1; Nominal Output Voltage: 15V; Mount: Surface Mount; Min Output Voltage: 15V; Min Operating Temperature: 0°C; Max Output Current: 500mA; Max Operating Temperature: 125°C; Length: 6.73mm; Lead Free: Lead Free; Height: 2.38mm; Halogen Free: Halogen Free; Current Rating: 500mA; Contact Plating: Tin,Matte; Component Kind: Standard; Case/Package: DPAK; Library Name: MY_Vregs.SchLib
U6 = 79M00-3PIN: ON SEMICONDUCTOR - MC79M15CDTRKG - NEGATIVE VOLTAGE REGULATOR, -15V DPAK-3; Power Supply Rejection Ratio (PSRR): 60dB; Output Voltage Accuracy: 4%; Output Type: Fixed; Output Current: 500mA; Number of Regulators: 1; Min Input Voltage: -17V; Max Output Voltage: -15.6V; Max Input Voltage: -35V; Dropout Voltage: 1.1V; Value: 79M15; Supplier Part Number: MC79M15CDTRKGOSDKR-ND; Supplier: Digi-Key; REACH SVHC: No SVHC; Radiation Hardening: No; Polarity: Negative; Packaging: Tape and Reel; Package Quantity: 1; Output Voltage: -15V; Number of Pins: 3; Number of Outputs: 1; Nominal Output Voltage: -15V; Mount: Surface Mount; Min Output Voltage: -15V; Min Operating Temperature: 0°C; Max Output Current: 500mA; Max Operating Temperature: 125°C; Manufacturer Part Number: MC79M15CDTRKG; Manufacturer: ON Semiconductor; Lead Free: Lead Free; Halogen Free: Halogen Free; Contact Plating: Tin,Matte; Component Kind: Standard; Case/Package: DPAK; Accuracy: 4%; Library Name: MY_Vregs.SchLib
D14 = Red: Library Name: My_LED.SchLib; Component Kind: Standard
T1 = TRIAD_VPP: Library Name: My_Trans.SchLib; Component Kind: Standard
T2 = TRIAD-F: Library Name: My_Trans.SchLib; Component Kind: Standard
